# Altium schematic sheet BiAxialLoadCell.SchDoc
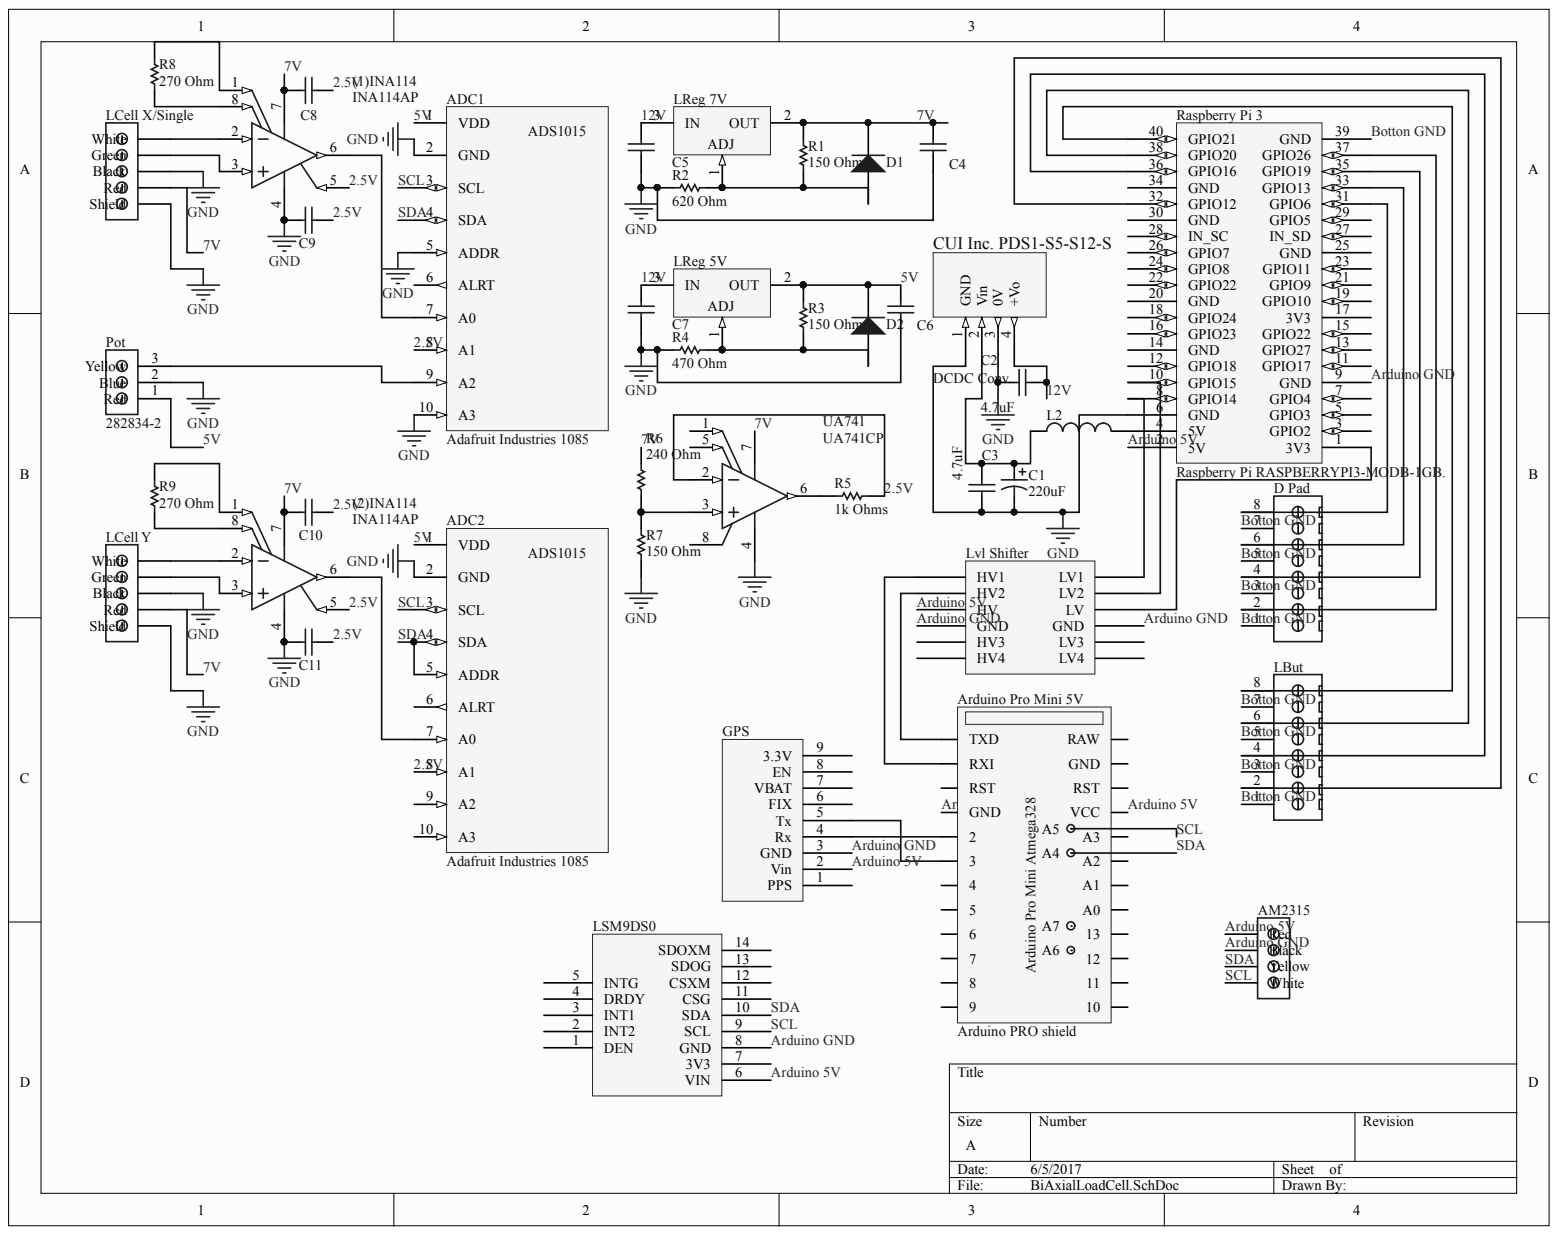
[diagram p0: BiAxialLoadCell.SchDoc]

## Parts
(1)INA114  INA114AP  [TI-P8]
(2)INA114  INA114AP  [TI-P8]
ADC1  Adafruit Industries 1085  [PCB-4lejntxigf7lhwnms15s-1]
ADC2  Adafruit Industries 1085  [PCB-4lejntxigf7lhwnms15s-1]
AM2315  282834-2  [PCB-pck2b3tw6vugzxi4asp2-1]  (value not drawn)
Arduino_Pro_Mini_5V  Arduino PRO shield  [PCB-xjupnshw5z1zqg394mrs-1]
C1  220uF  [PCB-kyskzfzvu5hkft7entwf-1]
C2  TDK FG28X5R1E475KRT06  [PCB-t6r2jniesmrfxoqfoh3w-1]  (value not drawn)
C3  TDK FG28X5R1E475KRT06  [PCB-t6r2jniesmrfxoqfoh3w-1]  (value not drawn)
C4  Vishay BCcomponents K104Z15Y5VF5TL2  [PCB-l4zci1ynknckpo24ombh-1]  (value not drawn)
C5  Vishay BCcomponents K104Z15Y5VF5TL2  [PCB-l4zci1ynknckpo24ombh-1]  (value not drawn)
C6  Vishay BCcomponents K104Z15Y5VF5TL2  [PCB-l4zci1ynknckpo24ombh-1]  (value not drawn)
C7  Vishay BCcomponents K104Z15Y5VF5TL2  [PCB-l4zci1ynknckpo24ombh-1]  (value not drawn)
C8  Vishay BCcomponents K104Z15Y5VF5TL2  [PCB-l4zci1ynknckpo24ombh-1]  (value not drawn)
C9  Vishay BCcomponents K104Z15Y5VF5TL2  [PCB-l4zci1ynknckpo24ombh-1]  (value not drawn)
C10  Vishay BCcomponents K104Z15Y5VF5TL2  [PCB-l4zci1ynknckpo24ombh-1]  (value not drawn)
C11  Vishay BCcomponents K104Z15Y5VF5TL2  [PCB-l4zci1ynknckpo24ombh-1]  (value not drawn)
D1  CMP-4964073-3  [ONSC-AXIAL_LEAD-2-59-10_P]  (value not drawn)
D2  CMP-4964073-3  [ONSC-AXIAL_LEAD-2-59-10_P]  (value not drawn)
D_Pad  TE Connectivity / AMP 282834-8  [PCB-31ifldq471oc3mn4sxz4-1]  (value not drawn)
DCDC_Conv  CUI PDS1-S5-S12-S  Manufacturer Part Number: PDS1-S5-S12-S  [PCB-vjduovkroff0l3ptlkf4-1]
GPS  Adafruit Industries 746  [PCB-bz3yr8570f4b4qxddo1b-1]  (value not drawn)
L2  Inductor  [PCB-mg0q4fr7srabzfbwe7jf-1]  (value not drawn)
LBut  TE Connectivity / AMP 282834-8  [PCB-31ifldq471oc3mn4sxz4-1]  (value not drawn)
LCell_X/Single  Color: Green  [PCB-pck2b3tw6vugzxi4asp2-1]  (hidden comment: TE Connectivity 282834-5)
LCell_Y  Color: Green  [PCB-pck2b3tw6vugzxi4asp2-1]  (hidden comment: TE Connectivity 282834-5)
LReg_5V  LM317T  [TO-220]  (value not drawn)
LReg_7V  LM317T  [TO-220]  (value not drawn)
LSM9DS0  Adafruit Industries 2021  [PCB-maed6y3qleiqj331msl7-1]  (value not drawn)
Lvl_Shifter  SparkFun BOB-12009  [PCB-snpsjs3ljinaye23s7fj-1]  (value not drawn)
Pot  282834-2  [PCB-3ptdhsghoqvd1l0ur6gj-1]
R1  150 Ohms  [PCB-vx15ok3iiu352umrckug-1]
R2  620 Ohm  [PCB-2034602-1]
R3  150 Ohms  [PCB-vx15ok3iiu352umrckug-1]
R4  470 Ohm  [PCB-ignmdqeaj071785ev1qy-1]
R5  1k Ohms  [PCB-bfjjg625tt0lscyu35rt-1]
R6  240 Ohm  [PCB-2034380-1]
R7  150 Ohm  [PCB-vx15ok3iiu352umrckug-1]
R8  270 Ohm  [PCB-3e396qau55ic585a88p4-1]
R9  270 Ohm  [PCB-3e396qau55ic585a88p4-1]
Raspberry_Pi_3  Raspberry Pi RASPBERRYPI3-MODB-1GB.  [PiHAT template]
UA741  UA741CP  [TI-P8]

## Nets
GND: (1)INA114.4 (2)INA114.4 ADC1.2(GND) ADC1.5(ADDR) ADC1.10(A3) ADC2.2(GND) C1.2 C2.2 C3.1 C4.1 C5.1 C6.1 C7.1 C9.2 C11.2 DCDC_Conv.1(GND) DCDC_Conv.3(0V) LCell_X/Single.1 LCell_X/Single.3 LCell_Y.1 LCell_Y.3 Pot.2 R2.1 R4.2 R7.1 Raspberry_Pi_3.6(GND) UA741.4
2.5V: (1)INA114.5 (2)INA114.5 ADC1.8(A1) ADC2.8(A1) C8.1 C9.1 C10.1 C11.1 R5.1 UA741.2
5V: ADC1.1(VDD) ADC2.1(VDD) C6.2 D2.2 LReg_5V.2 Pot.1 R3.2
7V: (1)INA114.7 (2)INA114.7 C4.2 C8.2 C10.2 D1.2 LCell_X/Single.2(Red) LCell_Y.2(Red) LReg_7V.2 R1.2 R6.2 UA741.7
12V: C2.1 C5.2 C7.2 DCDC_Conv.4(+Vo) LReg_5V.3 LReg_7V.3
ARDUINO 5V: AM2315.1 Arduino_Pro_Mini_5V.21(VCC) GPS.2(Vin) LSM9DS0.6(VIN) Lvl_Shifter.HV Raspberry_Pi_3.2(5V)
ARDUINO GND: AM2315.2 Arduino_Pro_Mini_5V.4(GND) GPS.3(GND) LSM9DS0.8(GND) Lvl_Shifter.GND Raspberry_Pi_3.9(GND)
BOTTON GND: D_Pad.1 D_Pad.3 D_Pad.5 D_Pad.7 LBut.1 LBut.3 LBut.5 LBut.7(GND) Raspberry_Pi_3.39(GND)
SCL: ADC1.3(SCL) ADC2.3(SCL) AM2315.4 Arduino_Pro_Mini_5V.28 LSM9DS0.9(SCL)
SDA: ADC1.4(SDA) ADC2.4(SDA) ADC2.5(ADDR) AM2315.3 Arduino_Pro_Mini_5V.27 LSM9DS0.10(SDA)
Net(1)INA114_1: (1)INA114.1 R8.2
Net(1)INA114_2: (1)INA114.2 LCell_X/Single.5
Net(1)INA114_3: (1)INA114.3 LCell_X/Single.4
Net(1)INA114_6: (1)INA114.6 ADC1.7(A0)
Net(1)INA114_8: (1)INA114.8 R8.1
Net(2)INA114_1: (2)INA114.1 R9.2
Net(2)INA114_2: (2)INA114.2 LCell_Y.5
Net(2)INA114_3: (2)INA114.3 LCell_Y.4
Net(2)INA114_6: (2)INA114.6 ADC2.7(A0)
Net(2)INA114_8: (2)INA114.8 R9.1
NetADC1_9: ADC1.9(A2) Pot.3
NetArduino Pro Mini 5V_1: Arduino_Pro_Mini_5V.1(TXD) Lvl_Shifter.HV2
NetArduino Pro Mini 5V_2: Arduino_Pro_Mini_5V.2(RXI) Lvl_Shifter.HV1
NetArduino Pro Mini 5V_5: Arduino_Pro_Mini_5V.5 GPS.4(Rx)
NetArduino Pro Mini 5V_6: Arduino_Pro_Mini_5V.6 GPS.5(Tx)
NetC1_1: C1.1 C3.2 DCDC_Conv.2(Vin) L2.1
NetD1_1: D1.1 LReg_7V.1 R1.1 R2.2
NetD2_1: D2.1 LReg_5V.1 R3.1 R4.1
NetD Pad_2: D_Pad.2 Raspberry_Pi_3.37(GPIO26)
NetD Pad_4: D_Pad.4 Raspberry_Pi_3.35(GPIO19)
NetD Pad_6: D_Pad.6 Raspberry_Pi_3.33(GPIO13)
NetD Pad_8: D_Pad.8 Raspberry_Pi_3.31(GPIO6)
NetL2_2: L2.2 Raspberry_Pi_3.4(5V)
NetLBut_2: LBut.2 Raspberry_Pi_3.32(GPIO12)
NetLBut_4: LBut.4 Raspberry_Pi_3.36(GPIO16)
NetLBut_6: LBut.6 Raspberry_Pi_3.38(GPIO20)
NetLBut_8: LBut.8 Raspberry_Pi_3.40(GPIO21)
NetLvl Shifter_LV: Lvl_Shifter.LV Raspberry_Pi_3.1(3V3)
NetLvl Shifter_LV1: Lvl_Shifter.LV1 Raspberry_Pi_3.8(GPIO14)
NetLvl Shifter_LV2: Lvl_Shifter.LV2 Raspberry_Pi_3.10(GPIO15)
NetR5_2: R5.2 UA741.6
NetR6_1: R6.1 R7.2 UA741.3

Not connected: ADC1 6(ALRT); ADC2 6(ALRT) 9(A2) 10(A3); Arduino_Pro_Mini_5V 3(RST) 7 8 9 10 11 12 13 14 15 16 17(A0) 18(A1) 19(A2) 20(A3) 22(RST) 23(GND) 24(RAW) 25 26; GPS 1(PPS) 6(FIX) 7(VBAT) 8(EN) 9(3.3V); LSM9DS0 1(DEN) 2(INT2) 3(INT1) 4(DRDY) 5(INTG) 7(3V3) 11(CSG) 12(CSXM) 13(SDOG) 14(SDOXM); Lvl_Shifter HV3 HV4 LV3 LV4; Raspberry_Pi_3 3(GPIO2) 5(GPIO3) 7(GPIO4) 11(GPIO17) 12(GPIO18) 13(GPIO27) 14(GND) 15(GPIO22) 16(GPIO23) 17(3V3) 18(GPIO24) 19(GPIO10) 20(GND) 21(GPIO9) 22(GPIO22) 23(GPIO11) 24(GPIO8) 25(GND) 26(GPIO7) 27(IN_SD) 28(IN_SC) 29(GPIO5) 30(GND) 34(GND); UA741 1 5 8

## Library data of the parts
(1)INA114 (2)INA114 = CMP-13608889-2: Precision Instrumentation Amplifier, -40 to 85 degC, 8-pin DIP (P8), Green (RoHS & no Sb/Br); Bandwidth: 1000000.0 Hz; Lead-Free Status: Lead Free; Number of Channels: 1; Quiescent Current: 0.0022 A; Slew Rate: 0.06 V/?s; Supply Voltage (DC): 18.0 V; Case/Package: SOIC; Component Kind: Standard; Library Name: CommunityVault; Lifecycle Status: Active; Mounting Style: Through Hole; Number of Circuits: 1; Number of Pins: 8; OctopartID: 2d8de4346353f24a; Octopart Url: https://octopart.com/ina114ap-texas+instruments-414192; Packaging: Tube; REACH SVHC Compliance: No SVHC; RoHS: Compliant; Supply Current: 0.0022 A
ADC1 ADC2 = CMP-311cf9d8a243eedb-16: ADS-1115 Programmable Gain Amplifier; Component Kind: Standard; Library Name: CommunityVault
AM2315 = CMP-e39628c790073c51-1: Conn; Term Blk; PCB; Wire Receptacle; 4; 2.54mm; 30-16AWG; Green; 10A; 150V; M1.6; Component Kind: Standard; Library Name: CommunityVault; Size-Height: 10.0 mm; Size-Length: 10.6 mm
Arduino_Pro_Mini_5V = CMP-14badecfe295cb7d-20: Arduino Pro Mini Atmega328 (3.3V /5V); Manufacturer Part Number: 2378; Component Kind: Standard; Library Name: CommunityVault; OctopartID: 14badecfe295cb7d; Octopart URL: http://octopart.com/2378-adafruit+industries-58708809
C1 = CMP-eaa62094da6d3561-1: Aluminium Electrolytic Capacitor, WCAP-ATG8 Series, 220 F, 20%, 25 V, 6.3 mm, Radial Leaded; Manufacturer Part Number: 860010473011; Capacitance: 0.00022 F; Voltage Rating (DC): 25.0 V; Capacitance Tolerance: ±20%; Component Kind: Standard; Library Name: CommunityVault; OctopartID: eaa62094da6d3561; Octopart URL: http://octopart.com/860010473011-w%C3%BCrth+elektronik-54007213; REACH SVHC Compliance: No SVHC; RoHS: Compliant; Size-Diameter: 0.0063 m; Size-Height: 0.011 m
C2 C3 = CMP-c1ff0cfb06121e38-1: CAP CER 4.7UF 25V X5R RADIAL; Component Kind: Standard; Library Name: CommunityVault; Manufacturer Part Number: FG28X5R1E475KRT06; OctopartID: c1ff0cfb06121e38; Octopart URL: http://octopart.com/fg28x5r1e475krt06-tdk-68084363
C4-C11 = CMP-7d20b42952c2a2e7-2: Capacitor; Ceramic; Cap 0.1 uF; Tol -20, +80%; Vol-Rtg 50 VDC; Radial; Y5V; Tape & Reel; Capacitance: 100 nF; Capacitance Tolerance: +80/-20%; Lead-Free Status: Contains Lead; Lead Length: 30.0 mm; Voltage Rating (DC): 50.0 V; Component Kind: Standard; Dielectric Characteristic: Y5V; Dielectric Material: Ceramic; Library Name: CommunityVault; Manufacturer Part Number: K104Z15Y5VF5TL2; Mounting Style: Through Hole; Number of Pins: 2; OctopartID: 7d20b42952c2a2e7; Octopart URL: http://octopart.com/k104z15y5vf5tl2-vishay+bccomponents-199300; Packaging: Cut Tape (CT), Tape & Reel (TR); RoHS: Compliant; Size-Diameter: 500 µm; Size-Height: 4.00 mm; Size-Length: 4.00 mm; Size-Thickness: 2.60 mm; Size-Width: 4.00 mm
D1 D2 = CMP-4964073-3: Standard Recovery Rectifier, 2-Pin Axial_Lead, Pb-Free, Tape and Reel; Current Rating: 1.0 A; Lead-Free Status: Lead Free; Voltage Rating (DC): 1000.0 V; Case/Package: DO-41; Component Kind: Standard; Halogen Free Status: Halogen Free; Library Name: CommunityVault; Lifecycle Status: Active; Mounting Style: Axial; Number of Pins: 2; OctopartID: e7602e6e287038a7; Octopart Url: https://octopart.com/1n4007rlg-on+semiconductor-322166; Packaging: Cut Tape (CT); Polarity: Standard; REACH SVHC Compliance: No SVHC; RoHS: Compliant
D_Pad LBut = CMP-2b39e0501fb7f4fe-6: Buchanan; Conn; Term Blk; PCB; 8; 2.54mm; Front; 30-16 AWG; Green; 10 A; 150 V; Component Kind: Standard; Library Name: CommunityVault
DCDC_Conv = CMP-2838e08a97fff7ff-2: PDS1 Series 1 W 12 VDC 83 mA Single Output DC-DC Isolated Converter; Lead-Free Status: Lead Free; Component Kind: Standard; Library Name: FavoritesLibrary; Lifecycle Status: Active; OctopartID: 2838e08a97fff7ff; Octopart URL: http://octopart.com/pds1-s5-s12-s-cui-28875425; RoHS: Compliant
GPS = CMP-5d1695eb4fdc82d1-6: Adafruit Ultimate GPS Breakout; Manufacturer Part Number: 746; Lead-Free Status: Lead Free; Supply Voltage (DC): 9.0 V; Component Kind: Standard; Library Name: CommunityVault; Lifecycle Status: Not Listed by Manufacturer; OctopartID: 5d1695eb4fdc82d1; Octopart URL: http://octopart.com/746-adafruit+industries-32978860; REACH SVHC Compliance: No SVHC; RoHS: Not Applicable; Weight: 200.0 g
L2 = CMP-92d25afd71424d15-2: High Frequency Split Core Ferrite Suppressors for Round Cable 835ohms 100MHz 5A Thru-hole; Lead-Free Status: Lead Free; Component Kind: Standard; Library Name: CommunityVault; Packaging: Bulk
LCell_X/Single LCell_Y = CMP-216fea3e502afea8-2: Buchanan; Conn; Term Blk; PCB; 5; 2.54mm; Front; 30-16 AWG; Green; 10 A; 150 V; ELV Compliant: Compliant; Lead-Free Status: Lead Free; Number of Contacts: 5; Component Kind: Standard; Contact Material: Brass; Contact Plating: Tin; Flammability Rating: UL 94 V-0; Housing Color: Green; Housing Material: Nylon 6/6; Library Name: CommunityVault; Lifecycle Status: Active; Manufacturer Part Number: 282834-5; Mounting Style: Through Hole, Screw; Number of Positions: 5; Number of Rows: 1; OctopartID: 216fea3e502afea8; Octopart URL: http://octopart.com/282834-5-te+connectivity-39539747; Packaging: Bulk; Pin Pitch: 2.54 mm; REACH SVHC Compliance: No SVHC; RoHS: Compliant; Size-Height: 10.0 mm; Size-Length: 13.2 mm; Size-Width: 6.50 mm
LReg_5V LReg_7V = CMP-1170851-2: 1.5A, 1.2V to 37V Adjustable Voltage Regulator, 3-Pin TO-220; Lead-Free Status: Lead Free; Number of Outputs: 1; Case/Package: TO-220; Component Kind: Standard; Library Name: CommunityVault; Lifecycle Status: Active; Mounting Style: Through Hole; Number of Pins: 3; OctopartID: 617dab3a1787beba; Octopart Url: https://octopart.com/lm317t-stmicroelectronics-55399324; Output Current: 1.5 A; Packaging: Tube; REACH SVHC Compliance: No SVHC; RoHS: Compliant
LSM9DS0 = CMP-d3cc19ecca900c0b-5: Adafruit 9-DOF Accel/Mag/Gyro+Temp Breakout Board; Manufacturer Part Number: 2021; Component Kind: Standard; Library Name: CommunityVault; Octopart URL: http://octopart.com/2021-adafruit+industries-53099578
Lvl_Shifter = CMP-a9a86355bbb8766f-7: Logic Level Converter - Bi-Direc; Component Kind: Standard; Library Name: CommunityVault; Manufacturer Part Number: BOB-12009; OctopartID: a9a86355bbb8766f; Octopart URL: http://octopart.com/bob-12009-sparkfun-66533238
Pot = CMP-2bf4e0a55a8ff433-1: Conn; Term Blk; PCB; Wire Receptacle; 3; 2.54mm; 30-16AWG; Green; 10A; 150V; M1.6; Number of Positions: 3; Component Kind: Standard; Contact Material: Brass; Contact Plating: Tin; Housing Material: Nylon 6/6; Library Name: CommunityVault; Lifecycle Status: Active; Orientation: Right Angle; REACH SVHC Compliance: No SVHC; RoHS: Compliant; Size-Height: 10.0 mm; Size-Length: 8.08 mm; Size-Width: 6.50 mm
R1 R3 R7 = CMP-aa5a626003c1759d-1: Through Hole Thin Film Resistor, LR Series, 150 ohm, 600 mW, - 1%, 350 V, Axial Leaded; Manufacturer Part Number: LR1F150R; ELV Compliant: Compliant; Power Rating: 600 mW; Resistance: 150 Ω; Voltage Rating (DC): 350 V; Component Kind: Standard; Library Name: CommunityVault; Lifecycle Status: Active; Mounting Style: Axial; OctopartID: aa5a626003c1759d; Octopart URL: http://octopart.com/lr1f150r-te+connectivity-19959037; Packaging: Ammo Pack; REACH SVHC Compliance: No SVHC; Resistance Tolerance: ±1%; RoHS: Compliant
R2 = CMP-08b0c1ebf079d777-1: RESISTOR, 0.25W 1% 620R; Series: MF25; Resistance: 620R; Tolerance, resistance: +/-1%; Power rating: 0.25W; Temperatu...; Manufacturer Part Number: MF25 620R; Power Rating: 250 mW; Component Kind: Standard; Library Name: CommunityVault; Number of Pins: 2; Octopart URL: http://octopart.com/mf25+620r-multicomp-5365167; Resistance Tolerance: ±1%; RoHS: Compliant
R4 = CMP-135bdb585537cc9c-1: Through Hole Metal Film Resistor, SFR16S Series, 470 kohm, 500 mW, - 5%, 200 V, Axial Leaded; Manufacturer Part Number: SFR16S0004703JA500; Lead-Free Status: Lead Free; Lead Length: 26.0 mm; Power Rating: 500 mW; Resistance: 470 kΩ; Voltage Rating (DC): 200 V; Component Kind: Standard; Library Name: CommunityVault; Number of Pins: 2; REACH SVHC Compliance: No SVHC; Resistance Tolerance: ±5%; RoHS: Compliant
R5 = CMP-db3e1311f37e04f9-1: Res Carbon Film 1K Ohm 5% 1/4W -400ppm/°C to 0ppm/°C Conformal AXL Thru-Hole T/R; Manufacturer Part Number: CF14JT1K00; Lead-Free Status: Lead Free; Component Kind: Standard; Library Name: CommunityVault; Mounting Style: Axial, Through Hole; OctopartID: db3e1311f37e04f9; Octopart URL: http://octopart.com/cf14jt1k00-stackpole+electronics-19205414; Packaging: Tape & Reel (TR); Resistance Tolerance: ±5%; RoHS: Compliant
R6 = CMP-2034380-1: RES 0.0 OHM 1/4W JUMP AXIAL; Manufacturer Part Number: CD14ZT0R00; Catalog Drawings: CF, CD Series; Dynamic Catalog: CD Series; Other Names: CD1/40RTR  CD1/40RTR-ND  CD14ZT0R00TR; Power (Watts): 0.25W, 1/4W; Product Change Notification: Global Part Number Change 9/Aug/2010; Resistance (Ohms): 0.0; Tolerance: Jumper; Category: Resistors; CiivaID: 2034380; Ciiva URL: https://ciiva.com/part/cd14zt0r00-2034380; Component Kind: Standard; Composition: Carbon Film; Family: Through Hole Resistors; Library Name: CommunityVault; Number of Terminations: 2; Package / Case: Axial; Packaging: Tape & Reel (TR); Series: CD; Size / Dimension: 0.091" Dia x 0.236" L (2.30mm x 6.00mm); Standard Package: 5,000; Supplier Device Package: Axial
R8 R9 = CMP-fd3b34c9d94622fc-2: Res Metal Film 270 Ohm 1% 0.6W ?50ppm/C Conformal Coated AXL Ammo Pack; Manufacturer Part Number: MF0207FTE52-270R; Component Kind: Standard; Library Name: CommunityVault; OctopartID: fd3b34c9d94622fc; Octopart URL: http://octopart.com/mf0207fte52-270r-yageo-16253211; RoHS: Compliant
Raspberry_Pi_3 = Raspberry Pi RASPBERRYPI3-MODB-1GB.-4: Raspberry Pi 3 Model B, 64bit, 1GB RAM, Wi-Fi 802.11n BT 4.1; Component Kind: Standard; Library Name: FavoritesLibrary
UA741 = CMP-1320630-2: General-Purpose Operational Amplifier, 7 to 36 V, 0 to 70 degC, 8-pin DIP (P8), Pb-Free (RoHS); Bandwidth: 1000000.0 Hz; Input Bias Current: 8e-08 A; Lead-Free Status: Lead Free; Number of Channels: 1; Rise Time: 3e-07 s; Slew Rate: 0.5 V/?s; Supply Current: 0.0017 A; Supply Voltage (DC): 15.0 V; Component Kind: Standard; Gain Bandwidth Product: 1000000.0 Hz; Library Name: CommunityVault; Lifecycle Status: Active; Mounting Style: Through Hole; Number of Circuits: 1; Number of Pins: 8; OctopartID: 5b43924966ea8484; Octopart Url: https://octopart.com/ua741cp-texas+instruments-6351; Packaging: Tube; REACH SVHC Compliance: No SVHC; RoHS: Compliant
